# Altium schematic sheet Port.SchDoc
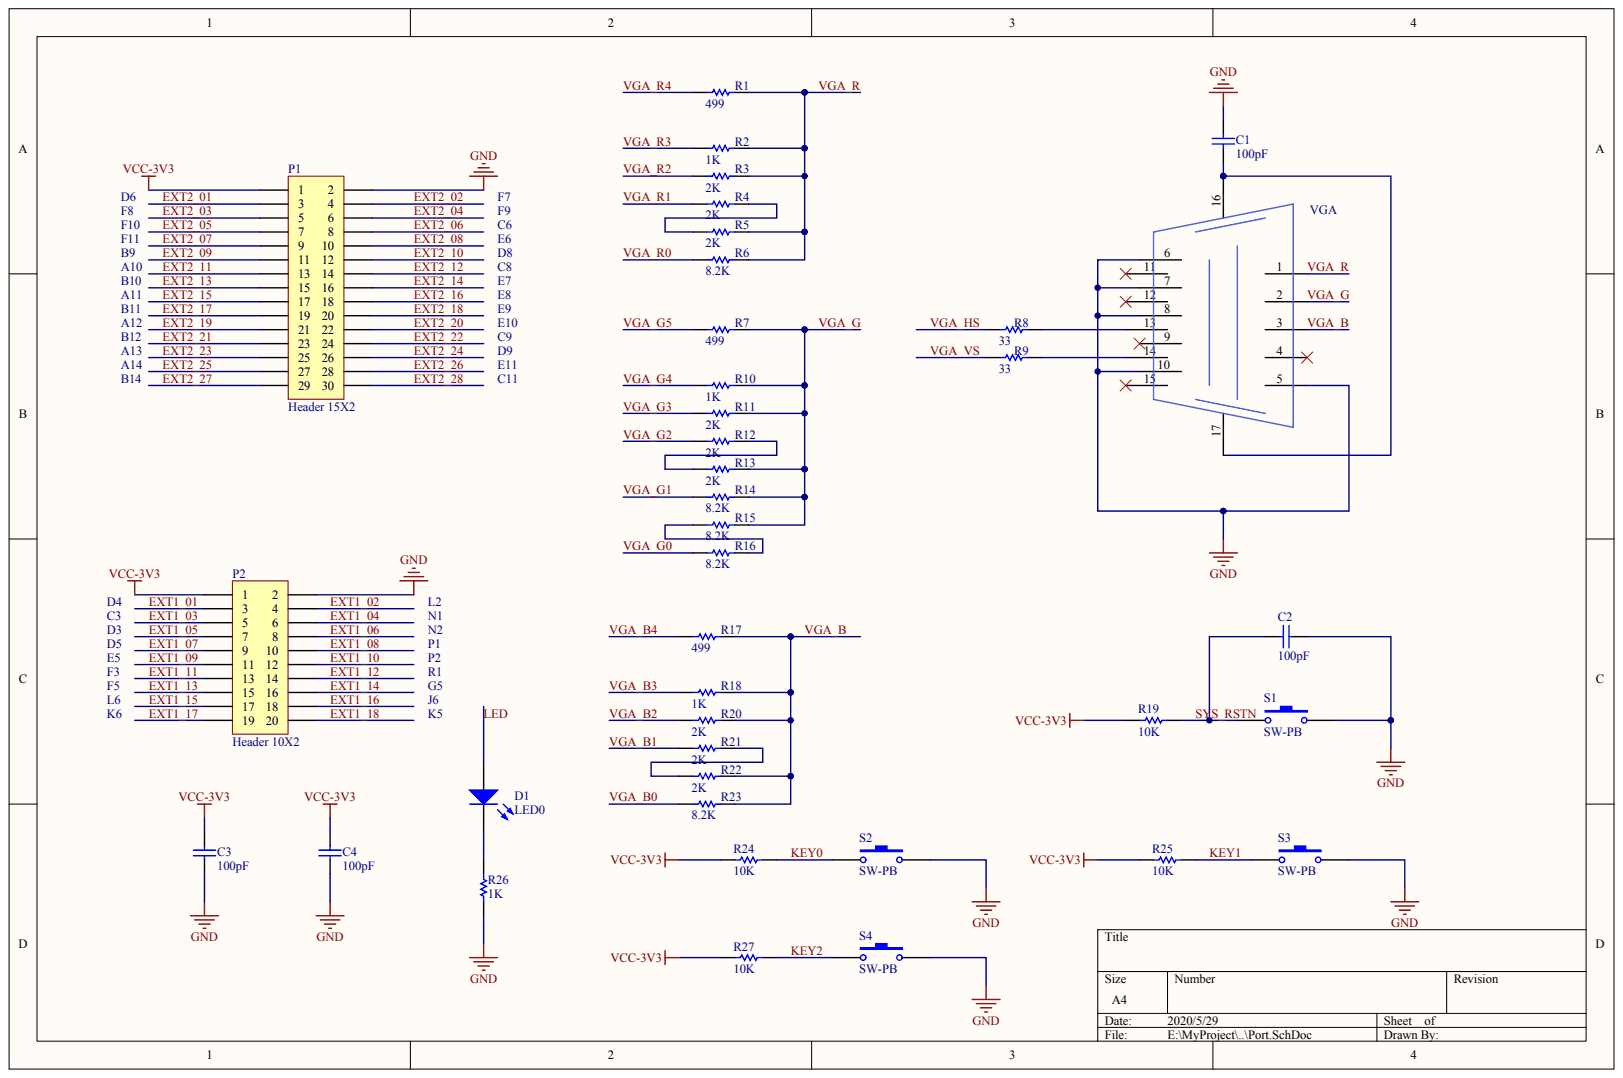
[diagram p0: Port.SchDoc]

## Parts
C1  value 100pF  (hidden comment: Cap)
C2  value 100pF  (hidden comment: Cap)
C3  value 100pF  (hidden comment: Cap)
C4  value 100pF  (hidden comment: Cap)
D1  LED0
P1  Header 15X2
P2  Header 10X2
R1  value 499  (hidden comment: Res1)
R2  value 1K  (hidden comment: Res1)
R3  value 2K  (hidden comment: Res1)
R4  value 2K  (hidden comment: Res1)
R5  value 2K  (hidden comment: Res1)
R6  value 8.2K  (hidden comment: Res1)
R7  value 499  (hidden comment: Res1)
R8  value 33  (hidden comment: Res1)
R9  value 33  (hidden comment: Res1)
R10  value 1K  (hidden comment: Res1)
R11  value 2K  (hidden comment: Res1)
R12  value 2K  (hidden comment: Res1)
R13  value 2K  (hidden comment: Res1)
R14  value 8.2K  (hidden comment: Res1)
R15  value 8.2K  (hidden comment: Res1)
R16  value 8.2K  (hidden comment: Res1)
R17  value 499  (hidden comment: Res1)
R18  value 1K  (hidden comment: Res1)
R19  value 10K  (hidden comment: Res1)
R20  value 2K  (hidden comment: Res1)
R21  value 2K  (hidden comment: Res1)
R22  value 2K  (hidden comment: Res1)
R23  value 8.2K  (hidden comment: Res1)
R24  value 10K  (hidden comment: Res1)
R25  value 10K  (hidden comment: Res1)
R26  value 1K  (hidden comment: Res1)
R27  value 10K  (hidden comment: Res1)
S1  SW-PB
S2  SW-PB
S3  SW-PB
S4  SW-PB
VGA1  VGA

## Nets
GND: C1.1 C2.1 C3.1 C4.1 P1.2 P2.2 R26.1 S1.2 S2.2 S3.2 S4.2 VGA1.5 VGA1.6 VGA1.7 VGA1.8 VGA1.10
VCC-3V3: C3.2 C4.2 P1.1 P2.1 R19.2 R24.2 R25.2 R27.2
EXT1_01: P2.3
EXT1_02: P2.4
EXT1_03: P2.5
EXT1_04: P2.6
EXT1_05: P2.7
EXT1_06: P2.8
EXT1_07: P2.9
EXT1_08: P2.10
EXT1_09: P2.11
EXT1_10: P2.12
EXT1_11: P2.13
EXT1_12: P2.14
EXT1_13: P2.15
EXT1_14: P2.16
EXT1_15: P2.17
EXT1_16: P2.18
EXT1_17: P2.19
EXT1_18: P2.20
EXT2_01: P1.3
EXT2_02: P1.4
EXT2_03: P1.5
EXT2_04: P1.6
EXT2_05: P1.7
EXT2_06: P1.8
EXT2_07: P1.9
EXT2_08: P1.10
EXT2_09: P1.11
EXT2_10: P1.12
EXT2_11: P1.13
EXT2_12: P1.14
EXT2_13: P1.15
EXT2_14: P1.16
EXT2_15: P1.17
EXT2_16: P1.18
EXT2_17: P1.19
EXT2_18: P1.20
EXT2_19: P1.21
EXT2_20: P1.22
EXT2_21: P1.23
EXT2_22: P1.24
EXT2_23: P1.25
EXT2_24: P1.26
EXT2_25: P1.27
EXT2_26: P1.28
EXT2_27: P1.29
EXT2_28: P1.30
KEY0: R24.1 S2.1
KEY1: R25.1 S3.1
KEY2: R27.1 S4.1
LED: D1.1
SYS_RSTN: C2.2 R19.1 S1.1
VGA_B: R17.1 R18.1 R20.1 R22.1 R23.1 VGA1.3
VGA_B0: R23.2
VGA_B1: R21.2
VGA_B2: R20.2
VGA_B3: R18.2
VGA_B4: R17.2
VGA_G: R7.1 R10.1 R11.1 R13.1 R14.1 R15.1 VGA1.2
VGA_G0: R16.2
VGA_G1: R14.2
VGA_G2: R12.2
VGA_G3: R11.2
VGA_G4: R10.2
VGA_G5: R7.2
VGA_HS: R8.2
VGA_R: R1.1 R2.1 R3.1 R5.1 R6.1 VGA1.1
VGA_R0: R6.2
VGA_R1: R4.2
VGA_R2: R3.2
VGA_R3: R2.2
VGA_R4: R1.2
VGA_VS: R9.2
NetC1_2: C1.2 VGA1.16 VGA1.17
NetD1_2: D1.2 R26.2
NetR4_1: R4.1 R5.2
NetR8_1: R8.1 VGA1.13
NetR9_1: R9.1 VGA1.14
NetR12_1: R12.1 R13.2
NetR15_2: R15.2 R16.1
NetR21_1: R21.1 R22.2

Not connected: VGA1 4 9 11 12 15

## Library data of the parts
C1-C4 D1 P1 P2 R1-R27 S1-S4 VGA1 = (no symbol name)
  C1-C4: Published: 8-Jun-2000; LatestRevisionDate: 17-Jul-2002; LatestRevisionNote: Re-released for DXP Platform.; PackageReference: RAD-0.3; PackageDescription: Radial Cap, Thru-Hole; 2 Leads; 0.3 in Pin Spacing
  D1: Published: 8-Jun-2000; LatestRevisionDate: 08-Jul-2005; LatestRevisionNote: Mech Layer 1 removed.; PackageReference: LED-0; PackageDescription: LED; 2 Leads
  P1 P2: LatestRevisionDate: 17-Jul-2002; LatestRevisionNote: Re-released for DXP Platform.
  R1-R27: Published: 8-Jun-2000; LatestRevisionDate: 17-Jul-2002; LatestRevisionNote: Re-released for DXP Platform.; PackageReference: AXIAL-0.3; PackageDescription: Axial Device, Thru-Hole; 2 Leads; 0.3 in Pin Spacing
  S1-S4: Published: 24-Mar-1999; LatestRevisionDate: 17-Jul-2002; LatestRevisionNote: Re-released for DXP Platform.; PackageReference: SPST-2; PackageDescription: Switch; 2 Leads
  C1-C4 D1 P1 P2 R1-R27 S1-S4: Publisher: Altium Limited
